# Altium schematic sheet Sheet2.SchDoc
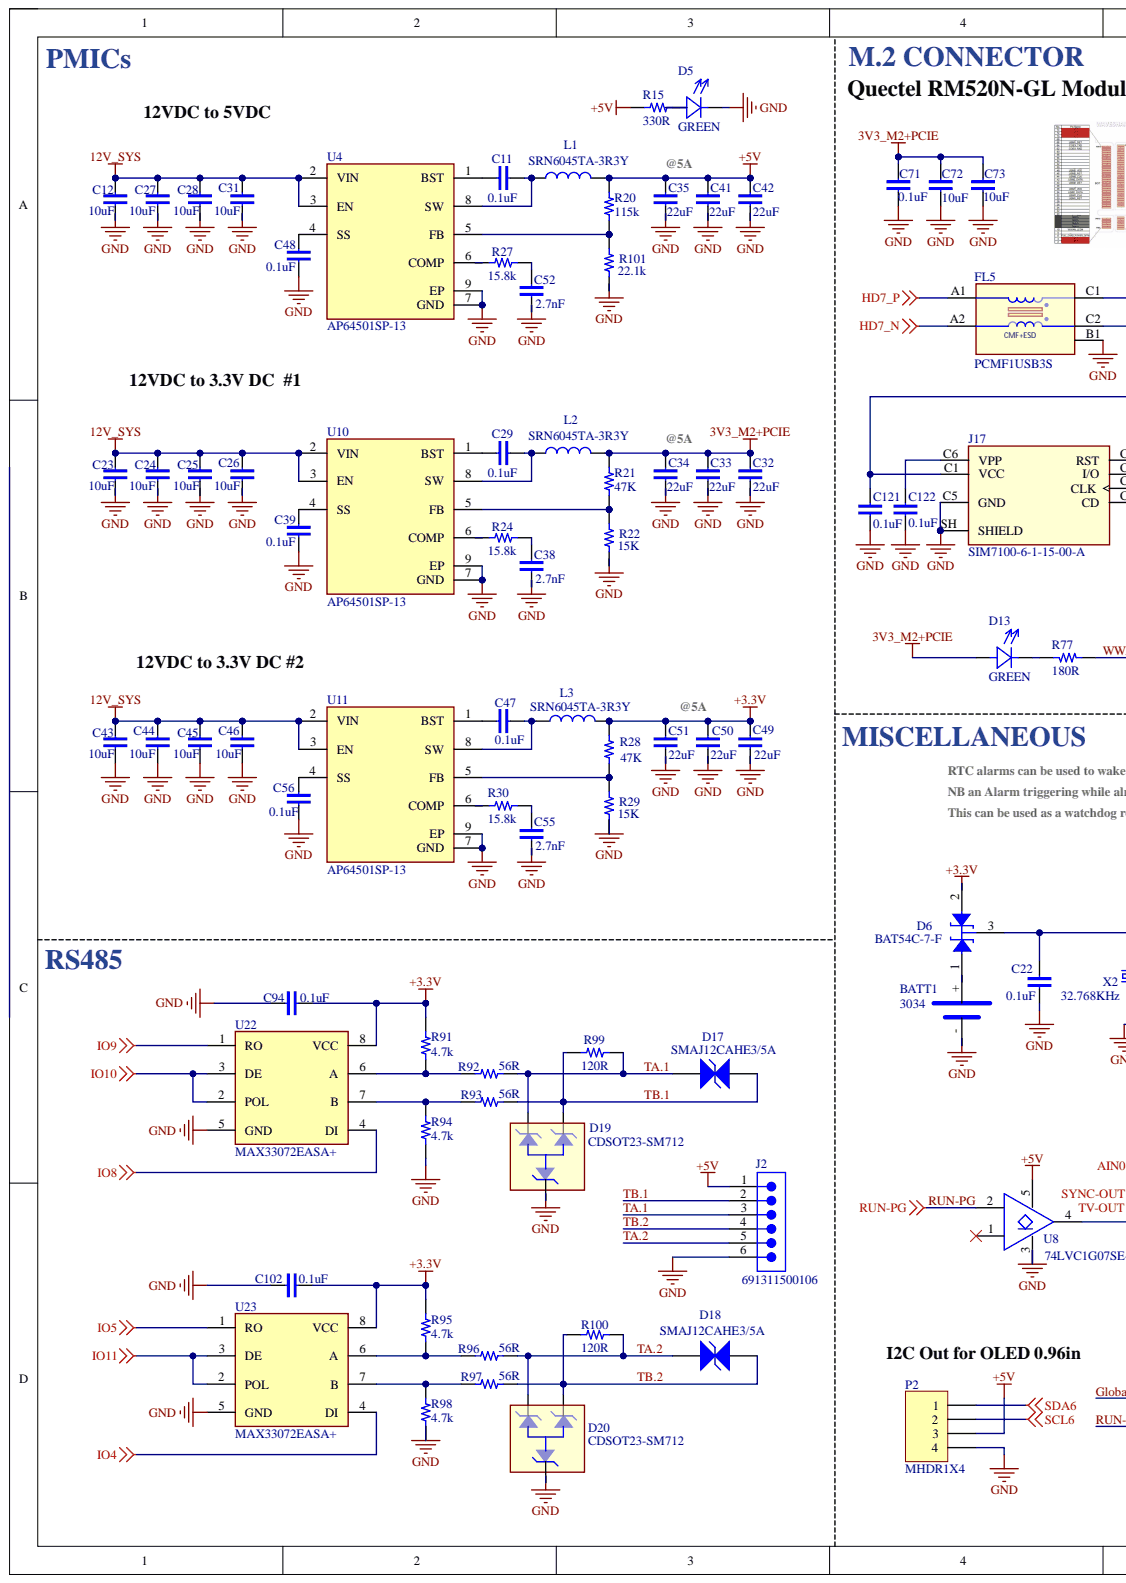
[diagram p0: Sheet2.SchDoc, left]
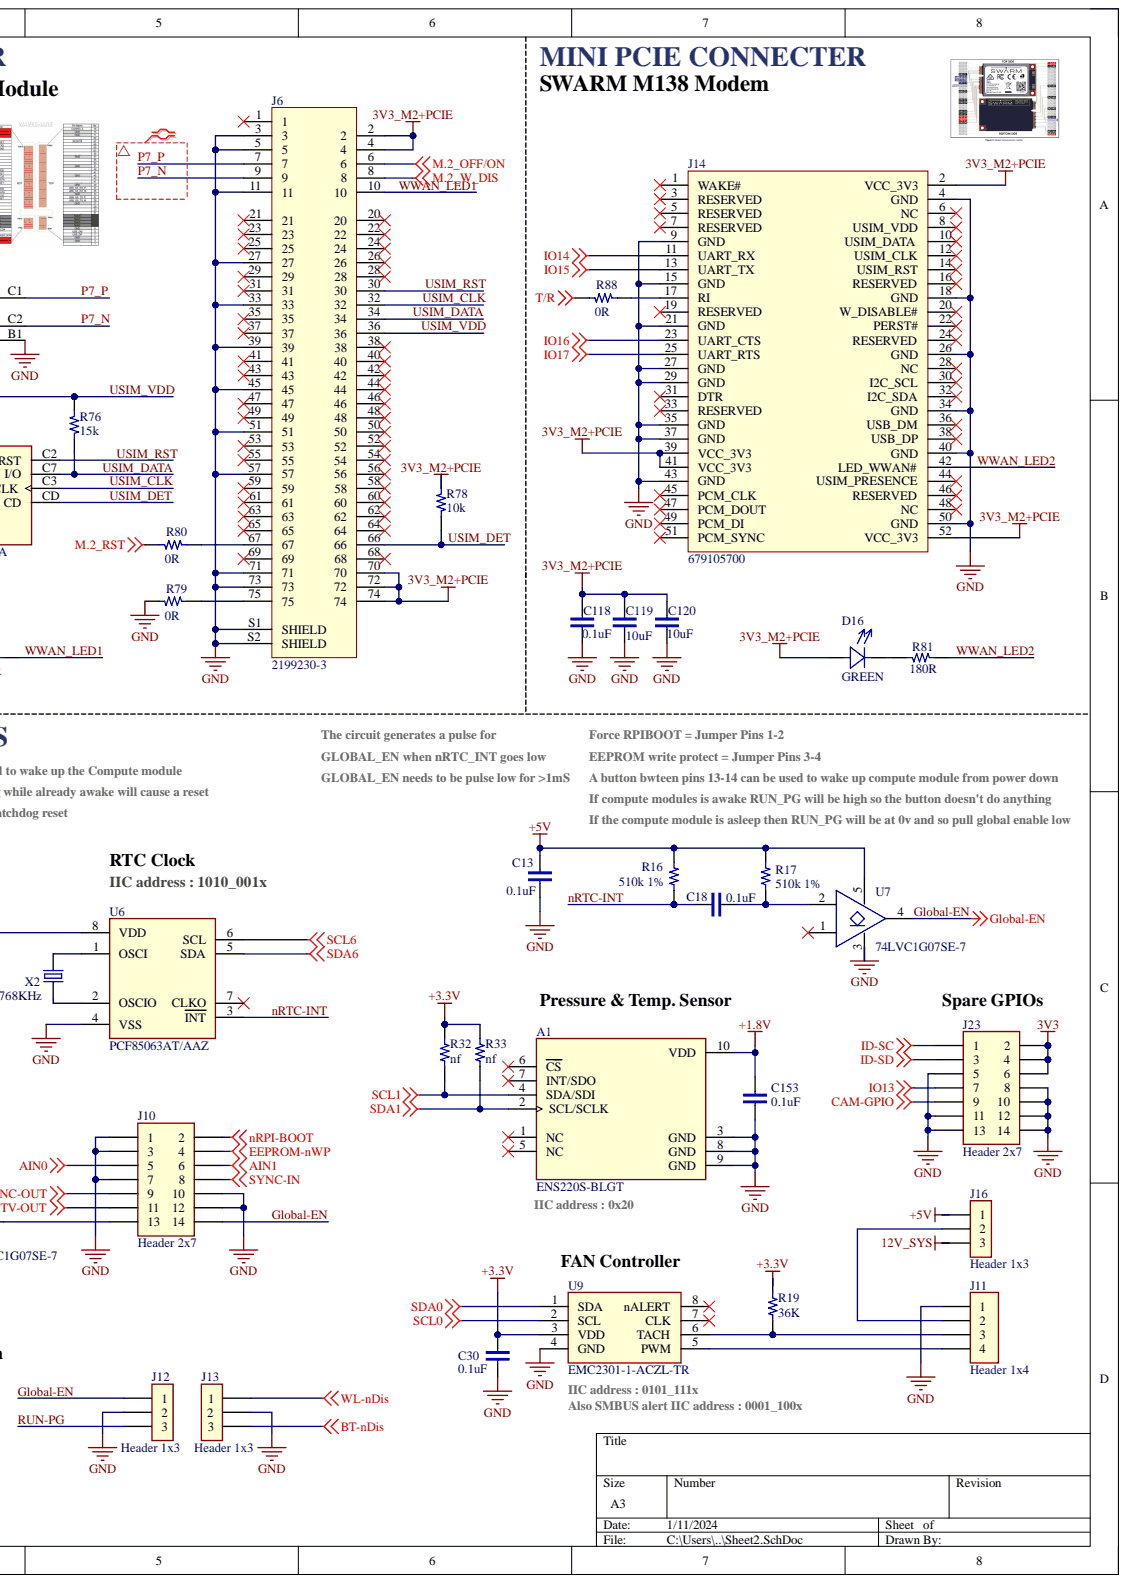
[diagram p1: Sheet2.SchDoc, right]

## Parts
A1  MP: ENS220S-BLGT  [XDCR_ENS220S-BLGT]
BATT1  Mfr. Part No.: 3034  [Keystone-3034]
C11  (no value)  [C-0402/1005]
C12  (no value)  [C-0805/2012]
C13  (no value)  [C-0402/1005]
C18  (no value)  [C-0402/1005]
C22  (no value)  [C-0402/1005]
C23  (no value)  [C-0805/2012]
C24  (no value)  [C-0805/2012]
C25  (no value)  [C-0805/2012]
C26  (no value)  [C-0805/2012]
C27  (no value)  [C-0805/2012]
C28  (no value)  [C-0805/2012]
C29  (no value)  [C-0402/1005]
C30  (no value)  [C-0402/1005]
C31  (no value)  [C-0805/2012]
C32  (no value)  [C-0805/2012]
C33  (no value)  [C-0805/2012]
C34  (no value)  [C-0805/2012]
C35  (no value)  [C-0805/2012]
C38  (no value)  [C-0402/1005]
C39  (no value)  [C-0402/1005]
C41  (no value)  [C-0805/2012]
C42  (no value)  [C-0805/2012]
C43  (no value)  [C-0805/2012]
C44  (no value)  [C-0805/2012]
C45  (no value)  [C-0805/2012]
C46  (no value)  [C-0805/2012]
C47  (no value)  [C-0402/1005]
C48  (no value)  [C-0402/1005]
C49  (no value)  [C-0805/2012]
C50  (no value)  [C-0805/2012]
C51  (no value)  [C-0805/2012]
C52  (no value)  [C-0402/1005]
C55  (no value)  [C-0402/1005]
C56  (no value)  [C-0402/1005]
C71  (no value)  [C-0402/1005]
C72  (no value)  [C-0603/1608]
C73  (no value)  [C-0603/1608]
C94  (no value)  [C-0402/1005]
C102  (no value)  [C-0402/1005]
C118  (no value)  [C-0402/1005]
C119  (no value)  [C-0603/1608]
C120  (no value)  [C-0603/1608]
C121  (no value)  [C-0402/1005]
C122  (no value)  [C-0402/1005]
C153  (no value)  [C-0402/1005]
D5  (no value)  [LED-0603-Green]
D6  Mfr. Part No.: BAT54C-7-F  [SOT-23-3]
D13  (no value)  [LED-0402-GREEN]
D16  (no value)  [LED-0402-GREEN]
D17  PartNumber: SMAJ12CAHE3/5A  [VISH-DO-214AC-2_L]
D18  PartNumber: SMAJ12CAHE3/5A  [VISH-DO-214AC-2_L]
D19  (no value)  [SOT-23-3]
D20  (no value)  [SOT-23-3]
FL5  value PCMF1USB3S  Manufacturer Part Number: PCMF1USB3S  [WLCSP5]
J2  (no value)  [691311500106]
J6  MP: 2199230-3  [TE_2199230-3]
J10  (no value)  [PIN HEADER 2x7 2.54mm]
J11  (no value)  [PIN HEADER 1x4 2.54mm]
J12  (no value)  [PIN HEADER 1x3 2.54mm]
J13  (no value)  [PIN HEADER 1x3 2.54mm]
J14  (no value)  [679105700-A]
J16  (no value)  [PIN HEADER 1x3 2.54mm]
J17  MP: SIM7100-6-1-15-00-A  [GCT_SIM7100-6-1-15-00-A_REVC]
J23  (no value)  [PIN HEADER 2x7 2.54mm]
L1  (no value)  [IND_SRN6045TA-3R3Y]
L2  (no value)  [IND_SRN6045TA-3R3Y]
L3  (no value)  [IND_SRN6045TA-3R3Y]
P2  (no value)  [PIN HEADER 1x4 2.54mm]
R15  (no value)  [R-0402/1005]
R16  value 510k 1%  [R-0402/1005]
R17  value 510k 1%  [R-0402/1005]
R19  (no value)  [R-0402/1005]
R20  (no value)  [R-0402/1005]
R21  (no value)  [R-0402/1005]
R22  (no value)  [R-0402/1005]
R24  (no value)  [R-0402/1005]
R27  (no value)  [R-0402/1005]
R28  (no value)  [R-0402/1005]
R29  (no value)  [R-0402/1005]
R30  (no value)  [R-0402/1005]
R32  (no value)  [R-0402/1005]
R33  (no value)  [R-0402/1005]
R76  (no value)  [R-0402/1005]
R77  (no value)  [R-0402/1005]
R78  (no value)  [R-0402/1005]
R79  (no value)  [R-0402/1005]
R80  (no value)  [R-0402/1005]
R81  (no value)  [R-0402/1005]
R88  (no value)  [R-0402/1005]
R91  (no value)  [R-0402/1005]
R92  (no value)  [R-0402/1005]
R93  (no value)  [R-0402/1005]
R94  (no value)  [R-0402/1005]
R95  (no value)  [R-0402/1005]
R96  (no value)  [R-0402/1005]
R97  (no value)  [R-0402/1005]
R98  (no value)  [R-0402/1005]
R99  (no value)  [R-0402/1005]
R100  (no value)  [R-0402/1005]
R101  (no value)  [R-0402/1005]
U4  (no value)  [FP-SO-8EP-IPC_A]
U6  Mfr. Part No.: PCF85063AT/AAZ  [SOIC-8 (W3.9mm)]
U7  Mfr. Part No.: 74LVC1G07SE-7  [SOT-353]
U8  Mfr. Part No.: 74LVC1G07SE-7  [SOT-353]
U9  (no value)  [SOP65P490X110-8N]
U10  (no value)  [FP-SO-8EP-IPC_A]
U11  (no value)  [FP-SO-8EP-IPC_A]
U22  (no value)  [FP-S8-4-IPC_B]
U23  (no value)  [FP-S8-4-IPC_B]
X2  (no value)  [XTAL3215]

## Nets
3V3: J23.2 J23.4 J23.6
3V3_M2+PCIE: C32.1 C33.1 C34.1 C71.1 C72.1 C73.1 C118.1 C119.1 C120.1 D13.1 D16.1 J6.2 J6.4 J6.70 J6.72 J6.74 J14.2(VCC_3V3) J14.39(VCC_3V3) J14.41(VCC_3V3) J14.52(VCC_3V3) L2.1 R21.1 R78.2
12V_SYS: C12.1 C23.1 C24.1 C25.1 C26.1 C27.1 C28.1 C31.1 C43.1 C44.1 C45.1 C46.1 J16.3 U4.2(VIN) U4.3(EN) U10.2(VIN) U10.3(EN) U11.2(VIN) U11.3(EN)
+1.8V: A1.10(VDD) C153.1
+3.3V: C30.1 C49.1 C50.1 C51.1 C94.2 C102.2 D6.2 L3.1 R19.1 R28.1 R32.1 R33.1 R91.2 R95.2 U9.3(VDD) U22.8(VCC) U23.8(VCC)
+5V: C13.1 C35.1 C41.1 C42.1 J2.1 J16.1 L1.1 P2.3 R15.2 R16.2 R17.2 R20.1 U7.5 U8.5
AIN0: J10.5
AIN1: J10.6
BT-nDis: J13.3
CAM-GPIO: J23.9
EEPROM-nWP: J10.4
GND: A1.3(GND) A1.8(GND) A1.9(GND) BATT1.- C12.2 C13.2 C22.2 C23.2 C24.2 C25.2 C26.2 C27.2 C28.2 C30.2 C31.2 C32.2 C33.2 C34.2 C35.2 C38.2 C39.2 C41.2 C42.2 C43.2 C44.2 C45.2 C46.2 C48.2 C49.2 C50.2 C51.2 C52.2 C55.2 C56.2 C71.2 C72.2 C73.2 C94.1 C102.1 C118.2 C119.2 C120.2 C121.2 C122.2 C153.2 D5.2 D19.3 D20.3 FL5.B1 J2.6 J6.3 J6.5 J6.11 J6.27 J6.33 J6.39 J6.45 J6.51 J6.57 J6.71 J6.73 J6.S1(SHIELD) J6.S2(SHIELD) J10.1 J10.3 J10.7 J10.10 J10.12 J11.1 J12.2 J13.2 J14.4(GND) J14.9(GND) J14.15(GND) J14.18(GND) J14.21(GND) J14.26(GND) J14.27(GND) J14.29(GND) J14.34(GND) J14.35(GND) J14.37(GND) J14.40(GND) J14.43(GND) J14.50(GND) J17.C5(GND) J17.SH(SHIELD) J23.5 J23.8 J23.10 J23.11 J23.12 J23.13 J23.14 P2.4 R22.2 R29.2 R79.2 R94.2 R98.2 R101.2 U4.7(GND) U4.9(EP) U6.4(VSS) U7.3 U8.3 U9.4(GND) U10.7(GND) U10.9(EP) U11.7(GND) U11.9(EP) U22.5(GND) U23.5(GND)
HD7_N: FL5.A2
HD7_P: FL5.A1
ID-SC: J23.1
ID-SD: J23.3
IO4: U23.4(DI)
IO5: U23.1(RO)
IO8: U22.4(DI)
IO9: U22.1(RO)
IO10: U22.2(POL) U22.3(DE)
IO11: U23.2(POL) U23.3(DE)
IO13: J23.7
IO14: J14.11(UART_RX)
IO15: J14.13(UART_TX)
IO16: J14.23(UART_CTS)
IO17: J14.25(UART_RTS)
M.2_OFF/ON: J6.6
M.2_RST: R80.2
M.2_W_DIS: J6.8
nRPI-BOOT: J10.2
SCL0: U9.2(SCL)
SCL1: A1.4(SDA/SDI) R32.2
SCL6: P2.2 U6.6(SCL)
SDA0: U9.1(SDA)
SDA1: A1.2(SCL/SCLK) R33.2
SDA6: P2.1 U6.5(SDA)
SYNC-IN: J10.8
SYNC-OUT: J10.9
T/R: R88.2
TV-OUT: J10.11
WL-nDis: J13.1
Global-EN: J10.14 J12.1 U7.4
nRTC-INT: C18.1 R16.1 U6.3(INT)
P7_N: FL5.C2 J6.9
P7_P: FL5.C1 J6.7
RUN-PG: J12.3 U8.2
TA.1: D17.1 D19.1 J2.3 R92.2 R99.1
TA.2: D18.1 D20.1 J2.5 R96.2 R100.1
TB.1: D17.2 D19.2 J2.2 R93.2 R99.2
TB.2: D18.2 D20.2 J2.4 R97.2 R100.2
USIM_CLK: J6.32 J17.C3(CLK)
USIM_DATA: J6.34 J17.C7(I/O) R76.1
USIM_DET: J6.66 J17.CD R78.1
USIM_RST: J6.30 J17.C2(RST)
USIM_VDD: C121.1 J6.36 J17.C1(VCC) R76.2
WWAN_LED1: J6.10 R77.1
WWAN_LED2: J14.42(LED_WWAN#) R81.1
NetBATT1_+: BATT1.+ D6.1
NetC11_1: C11.1 L1.2 U4.8(SW)
NetC11_2: C11.2 U4.1(BST)
NetC18_2: C18.2 R17.1 U7.2
NetC22_1: C22.1 D6.3 U6.8(VDD)
NetC29_1: C29.1 L2.2 U10.8(SW)
NetC29_2: C29.2 U10.1(BST)
NetC38_1: C38.1 R24.2
NetC39_1: C39.1 U10.4(SS)
NetC47_1: C47.1 L3.2 U11.8(SW)
NetC47_2: C47.2 U11.1(BST)
NetC48_1: C48.1 U4.4(SS)
NetC52_1: C52.1 R27.2
NetC55_1: C55.1 R30.2
NetC56_1: C56.1 U11.4(SS)
NetC122_1: C122.1 J17.C6(VPP)
NetD5_1: D5.1 R15.1
NetD13_2: D13.2 R77.2
NetD16_2: D16.2 R81.2
NetJ6_67: J6.67 R80.1
NetJ6_75: J6.75 R79.1
NetJ10_13: J10.13 U8.4
NetJ11_2: J11.2 J16.2
NetJ11_3: J11.3 R19.2 U9.6(TACH)
NetJ11_4: J11.4 U9.5(PWM)
NetJ14_17: J14.17(RI) R88.1
NetR20_2: R20.2 R101.1 U4.5(FB)
NetR21_2: R21.2 R22.1 U10.5(FB)
NetR24_1: R24.1 U10.6(COMP)
NetR27_1: R27.1 U4.6(COMP)
NetR28_2: R28.2 R29.1 U11.5(FB)
NetR30_1: R30.1 U11.6(COMP)
NetR91_1: R91.1 R92.1 U22.6(A)
NetR93_1: R93.1 R94.1 U22.7(B)
NetR95_1: R95.1 R96.1 U23.6(A)
NetR97_1: R97.1 R98.1 U23.7(B)
NetU6_1: U6.1(OSCI) X2.2
NetU6_2: U6.2(OSCIO) X2.1

Not connected: A1 1(NC) 5(NC) 6(CS) 7(INT/SDO); J6 1 20 21 22 23 24 25 26 28 29 31 35 37 38 40 41 42 43 44 46 47 48 49 50 52 53 54 55 56 58 59 60 61 62 63 64 65 68 69; J14 1(WAKE#) 3(RESERVED) 5(RESERVED) 6(NC) 7(RESERVED) 8(USIM_VDD) 10(USIM_DATA) 12(USIM_CLK) 14(USIM_RST) 16(RESERVED) 19(RESERVED) 20(W_DISABLE#) 22(PERST#) 24(RESERVED) 28(NC) 30(I2C_SCL) 31(DTR) 32(I2C_SDA) 33(RESERVED) 36(USB_DM) 38(USB_DP) 44(USIM_PRESENCE) 45(PCM_CLK) 46(RESERVED) 47(PCM_DOUT) 48(NC) 49(PCM_DI) 51(PCM_SYNC); U6 7(CLKO); U7 1; U8 1; U9 7(CLK) 8(nALERT)

## Library data of the parts
A1 = (no symbol name): Board Mount Pressure Sensors ENS220S-BLGT LGA10 T&R 3K5 - Premium accuracy barometric pressureand temperature sensor for activitytracking and indoor navigation /localization; MF: ScioSense; Check_prices: https://www.snapeda.com/parts/ENS220S-BLGT/ScioSense/view-part/?ref=eda; Package: None; SnapEDA_Link: https://www.snapeda.com/parts/ENS220S-BLGT/ScioSense/view-part/?ref=snap
BATT1 = (no symbol name): BATTERY RETAINER COIN 20MM SMD; Unit Price (USD): 0.33; Supplier: Digikey Electronics; Supplier Part No.: 36-3034-ND
C11 C12 C23-C35 C38 C39 C41-C52 C55 C56 = (no symbol name): CAP CER 10UF 25V X5R 1206; Mfr. Part No.: C1206C106M3PACTU; Unit Price (USD): 0.28; Supplier: Digikey Electronics; Supplier Part No.: 399-8153-1-ND
C13 C18 C22 C121 C122 C153 = (no symbol name): CAP CER 0.1UF 16V X7R 0402; Mfr. Part No.: GCM155R71C104KA55D; Unit Price (USD): 0.1; Supplier: Digikey Electronics; Supplier Part No.: 490-4759-1-ND; Value: 0.1uF 16V
C71-C73 C94 C102 C118-C120 = (no symbol name): CAP CER 0.1UF 50V X7R 0402; Mfr. Part No.: UMK105B7104KV-FR; Unit Price (USD): 0.15; Value: 100nF; Supplier: Digikey Electronics; Supplier Part No.: 587-3498-1-ND
D6 = (no symbol name): DIODE ARRAY SCHOTTKY 30V SOT23-3; Unit Price (USD): 0.18; Supplier: Digikey Electronics; Supplier Part No.: BAT54C-FDICT-ND
D17 D18 = (no symbol name): Transient Voltage Suppressor, 6.40 to 231 V, 12 V Stand-off Voltage, Bi-Directional, -55 to 155 degC, 2-pin DO-214AC, Pb-Free, Tape and Reel; Manufacturer: Vishay Semiconductor; ID (uA): 2; IFSM: 40 A; PPPM (W): 400, 300; VBR Bi-Directional (V): 6.4 to 231; VWM (V): 12; ComponentLink1Description: Manufacturer URL; ComponentLink1URL: http://www.vishay.com/; ComponentLink2Description: Datasheet; ComponentLink2URL: http://www.vishay.com/docs/88390/smaj50a.pdf; ComponentLink3Description: Package Specification; ComponentLink3URL: http://www.vishay.com/docs/88390/smaj50a.pdf; DatasheetVersion: 12/8/2013; Mounting Technology: Surface Mount; Operating Junction and Storage Temperature Range: -55 to 150 degC; PackageDescription: 2-Pin DO-214AC (SMA), Body 4.245 x 2.665 mm; PackageReference: DO-214AC-2; Packing: Tape and Reel; RoHS: TRUE
D19 D20 = (no symbol name): TVS DIODE 3.5V 6.5V SLP1006N3T; Mfr. Part No.: RCLAMP3552T.TNT; Unit Price (USD): 0.48; Supplier: Digikey Electronics; Supplier Part No.: RCLAMP3552T.TNTCT-ND
FL5 = (no symbol name): FLT, SMT, WLCSP5, Common Mode EMI Filters; Manufacturer: Nexperia; Notes: NC; Substitutes Allowed: NO; Datasheet Link: https://www.nexperia.com/products/esd-protection-tvs-filtering-and-signal-conditioning/emi-solutions-with-integrated-protection/common-mode-filters-with-integrated-protection/PCMF1USB3S.html; Height: 0.6mm; Mounting: SMT; Operating Temperature: -40ºC ~ +125ºC; Subpart Type: Common Mode EMI Filters; Supply Current: NO; Supply Voltage: NO
J2 = (no symbol name): NexarPartId: 8917751; Current: 20A; Gender: Male; Number of Contacts: 6; Number of Levels: 1; Pitch: 5.08mm; Voltage: 300V; Color: Green; Contact Material: Brass; Contact Plating: Tin; Height: 12mm; Lead Pitch: 5.08mm; Length: 8.5mm; Mount: Through Hole; Number of Pins: 6; Number of Positions Per Level: 6; Number of Rows: 1; Orientation: Vertical; Packaging: Box; REACH SVHC: Unknown; RoHS: Compliant; Termination: Solder; Width: 32.48mm
J6 = (no symbol name): M.2 0.5PITCH 4.2H KEY B 15U'' AU; Availability: Unavailable; Package: None; Price: None
J10 J23 = (no symbol name): CONN HEADER VERT 14POS 2.54MM; Mfr. Part No.: PRPC007DFBN-RC; Unit Price (USD): 0.47; Supplier: Digikey Electronics; Supplier Part No.: S2221EC-07-ND
J11 = (no symbol name): CONN HEADER VERT 4POS 2.54MM; Supplier: Digikey Electronics
J12 J13 J16 = (no symbol name): CONN HEADER VERT 3POS 2.54MM; Mfr. Part No.: 75160-156-03LF; Unit Price (USD): 0.74; Value: 51R; Supplier: Digikey Electronics; Supplier Part No.: 609-75160-156-03LF-ND
J17 = (no symbol name): 7 _6 + 1_ Position Card Connector Micro SIM Surface Mount, Right Angle Gold; MF: Global Connector Technology; Availability: Unavailable; Package: None; Price: None; SnapEDA_Link: https://www.snapeda.com/parts/SIM7100-6-1-15-00-A/Global%20Connector%20Technology/view-part/449163/?ref=snap
L1-L3 = (no symbol name): FIXED IND 22UH 620MA 350 MOHM; Mfr. Part No.: ASPI-4020S-220M-T; Unit Price (USD): 0.48; Supplier: Digikey Electronics; Supplier Part No.: 535-12307-1-ND; Value: 22uH
P2 = (no symbol name): Header, 4-Pin; LatestRevisionDate: 17-Jul-2002; LatestRevisionNote: Re-released for DXP Platform.; Publisher: Altium Limited
R15 R19-R22 R24 R27-R30 R76-R81 R88 R91-R101 = (no symbol name): RES SMD 51 OHM 0.5% 1/16W 0402; Mfr. Part No.: ERA-2AED510X; Unit Price (USD): 0.21; Value: 51R; Supplier: Digikey Electronics; Supplier Part No.: P51DECT-ND
R16 = (no symbol name): RES SMD 510K OHM 1% 1/16W 0402; Mfr. Part No.: MCR01MZPF5103; Unit Price (USD): 0.1; Supplier: Digikey Electronics; Supplier Part No.: RHM510KLCT-ND
R17 = (no symbol name): RES SMD 510K OHM 1% 1/16W 0402; Mfr. Part No.: MCR01MZPF5103; Unit Price (USD): 0.1; Value: 510k 1%; Supplier: Digikey Electronics; Supplier Part No.: RHM510KLCT-ND
R32 R33 = (no symbol name): RES SMD 1K OHM 1% 1/16W 0402; Mfr. Part No.: RC0402FR-071KL; Unit Price (USD): 0.1; Supplier: Digikey Electronics; Supplier Part No.: 311-1.00KLRCT-ND; Value: 1k
U4 U10 U11 = (no symbol name): DCDC CONV HV BUCK SO-8EP
U6 = (no symbol name): IC RTC CLK/CALENDAR I2C 8SOIC; Unit Price (USD): 0.335; Supplier: Digikey Electronics; Supplier Part No.: 568-PCF85063AT/AAZCT-ND
U7 U8 = (no symbol name): IC BUF NON-INVERT 5.5V SOT353; Unit Price (USD): 0.26; Supplier: Digikey Electronics; Supplier Part No.: 74LVC1G07SE-7DICT-ND
U22 U23 = (no symbol name): DUAL VOLTAGE RS-485, 70V FP, 40V
X2 = (no symbol name): CRYSTAL 32.7680KHZ 7PF SMD 20ppm; Mfr. Part No.: CM7V-T1A-32.768KHZ-7PF-20PPM-TB-QA; Unit Price (USD): 0.42; Supplier: Digikey Electronics; Supplier Part No.: 2195-CM7V-T1A-32.768KHZ-7PF-20PPM-TB-QACT-ND
D5 D13 D16 J14 U9 = (no symbol name)
  D5 D13 D16: Supplier: Digikey Electronics
